# Altium schematic sheet 01_Interconnect.SchDoc(01_Interconnect)
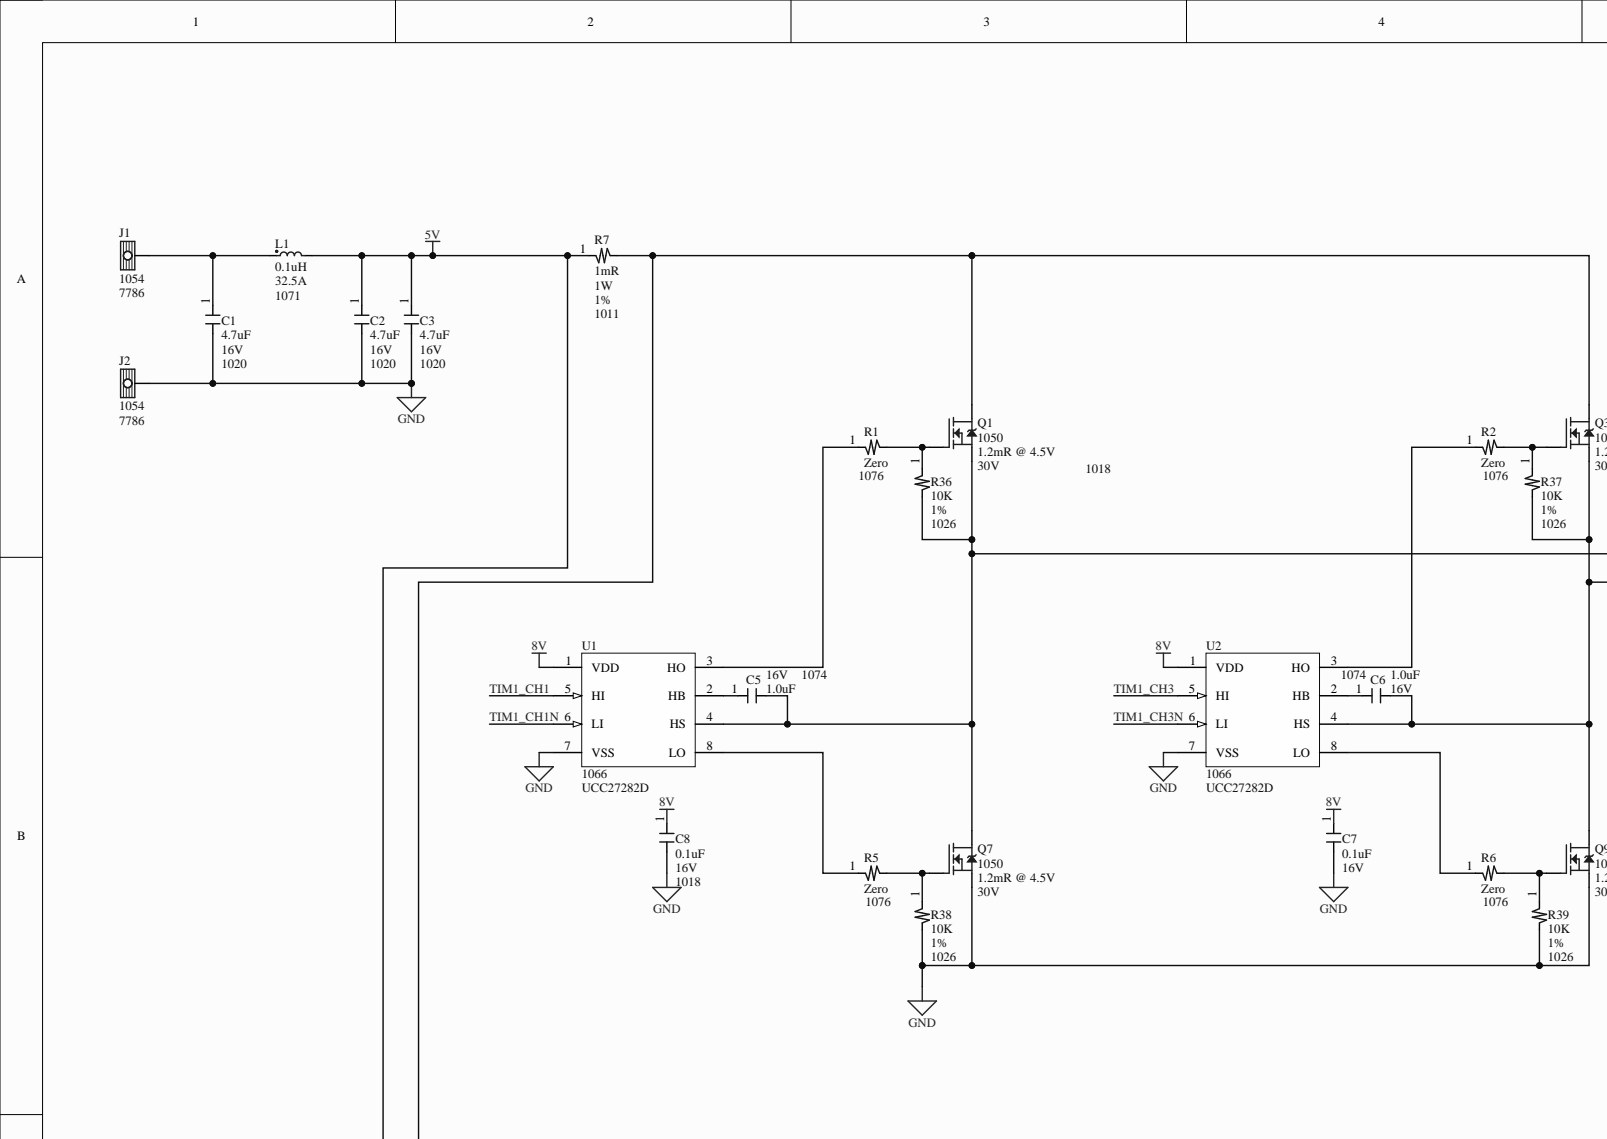
[diagram p0: 01_Interconnect.SchDoc, top left]
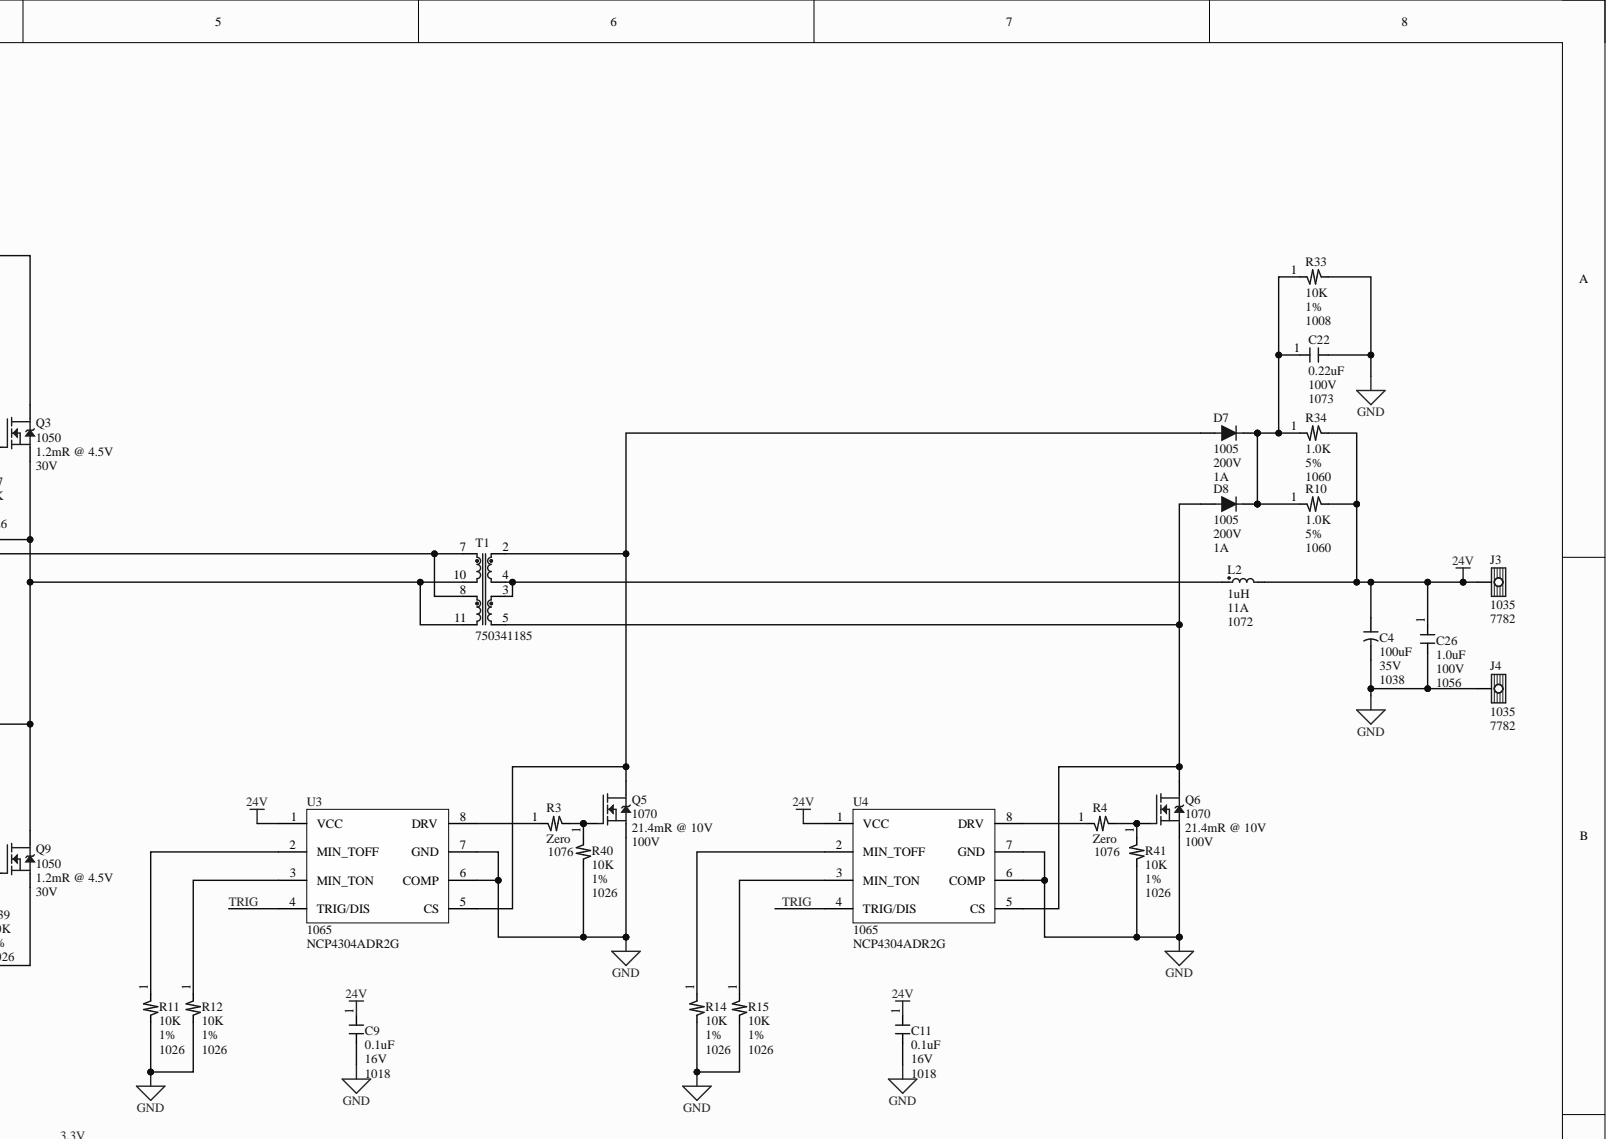
[diagram p1: 01_Interconnect.SchDoc, top right]
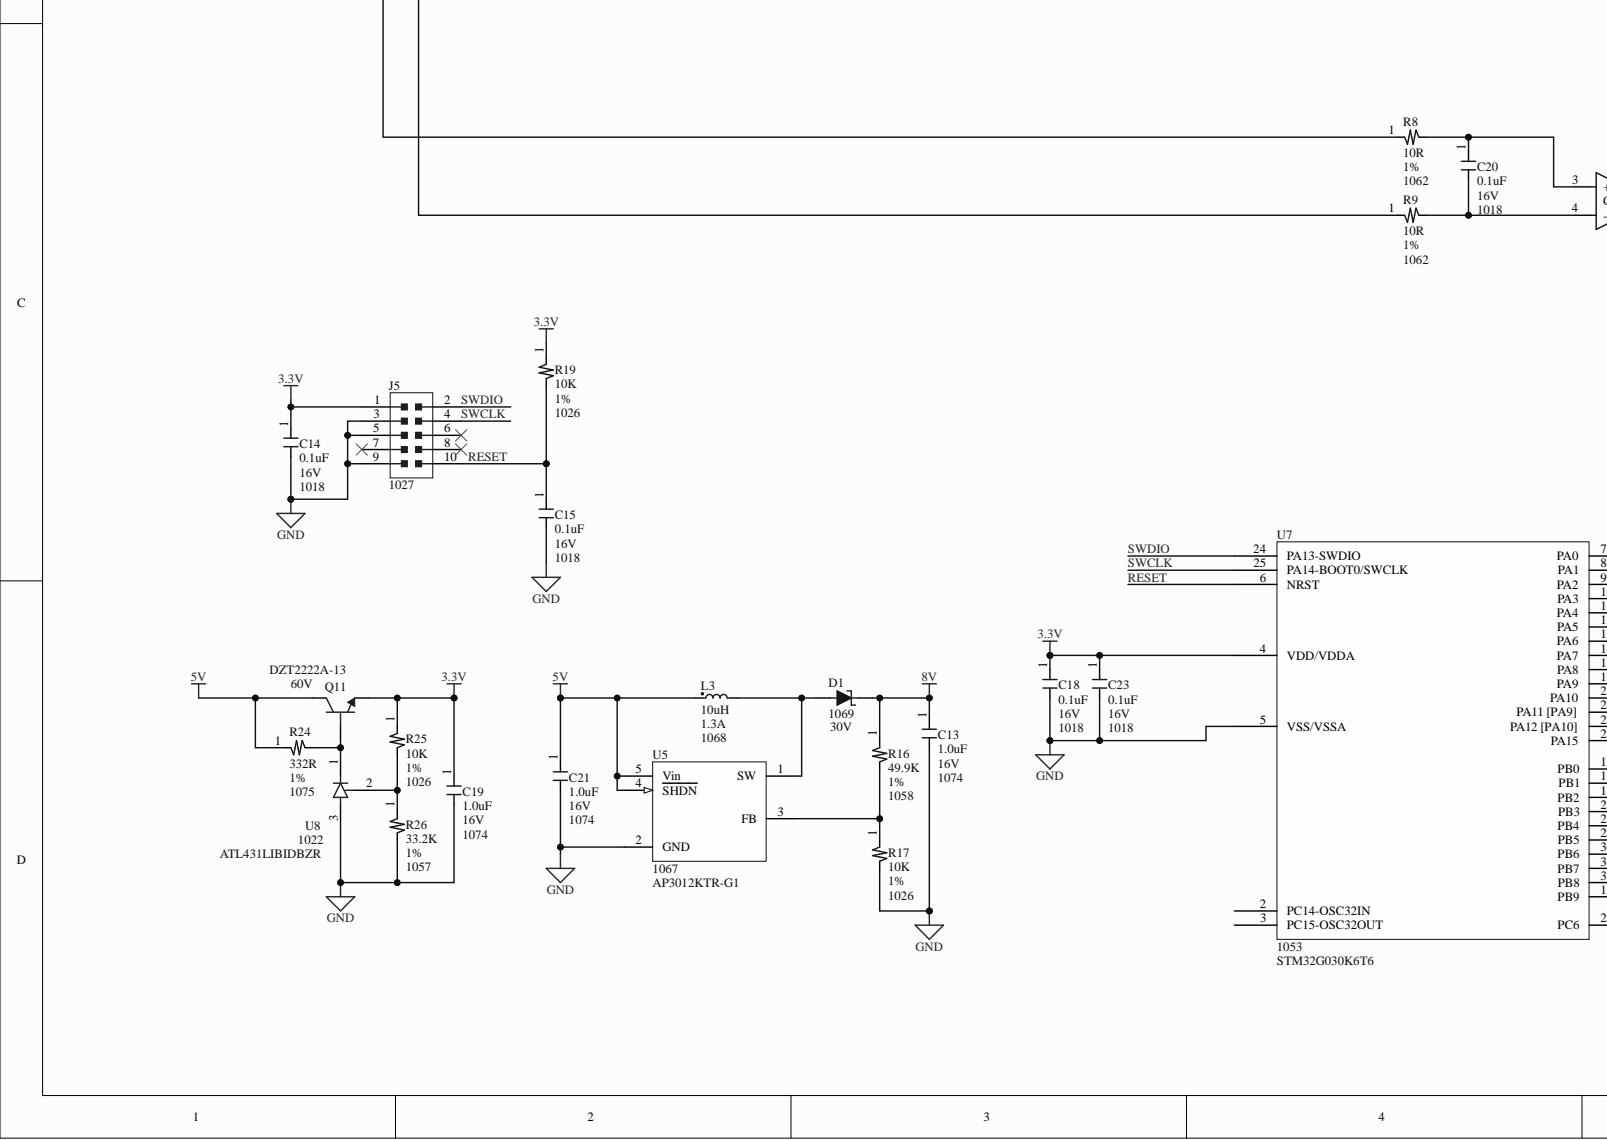
[diagram p2: 01_Interconnect.SchDoc, bottom left]
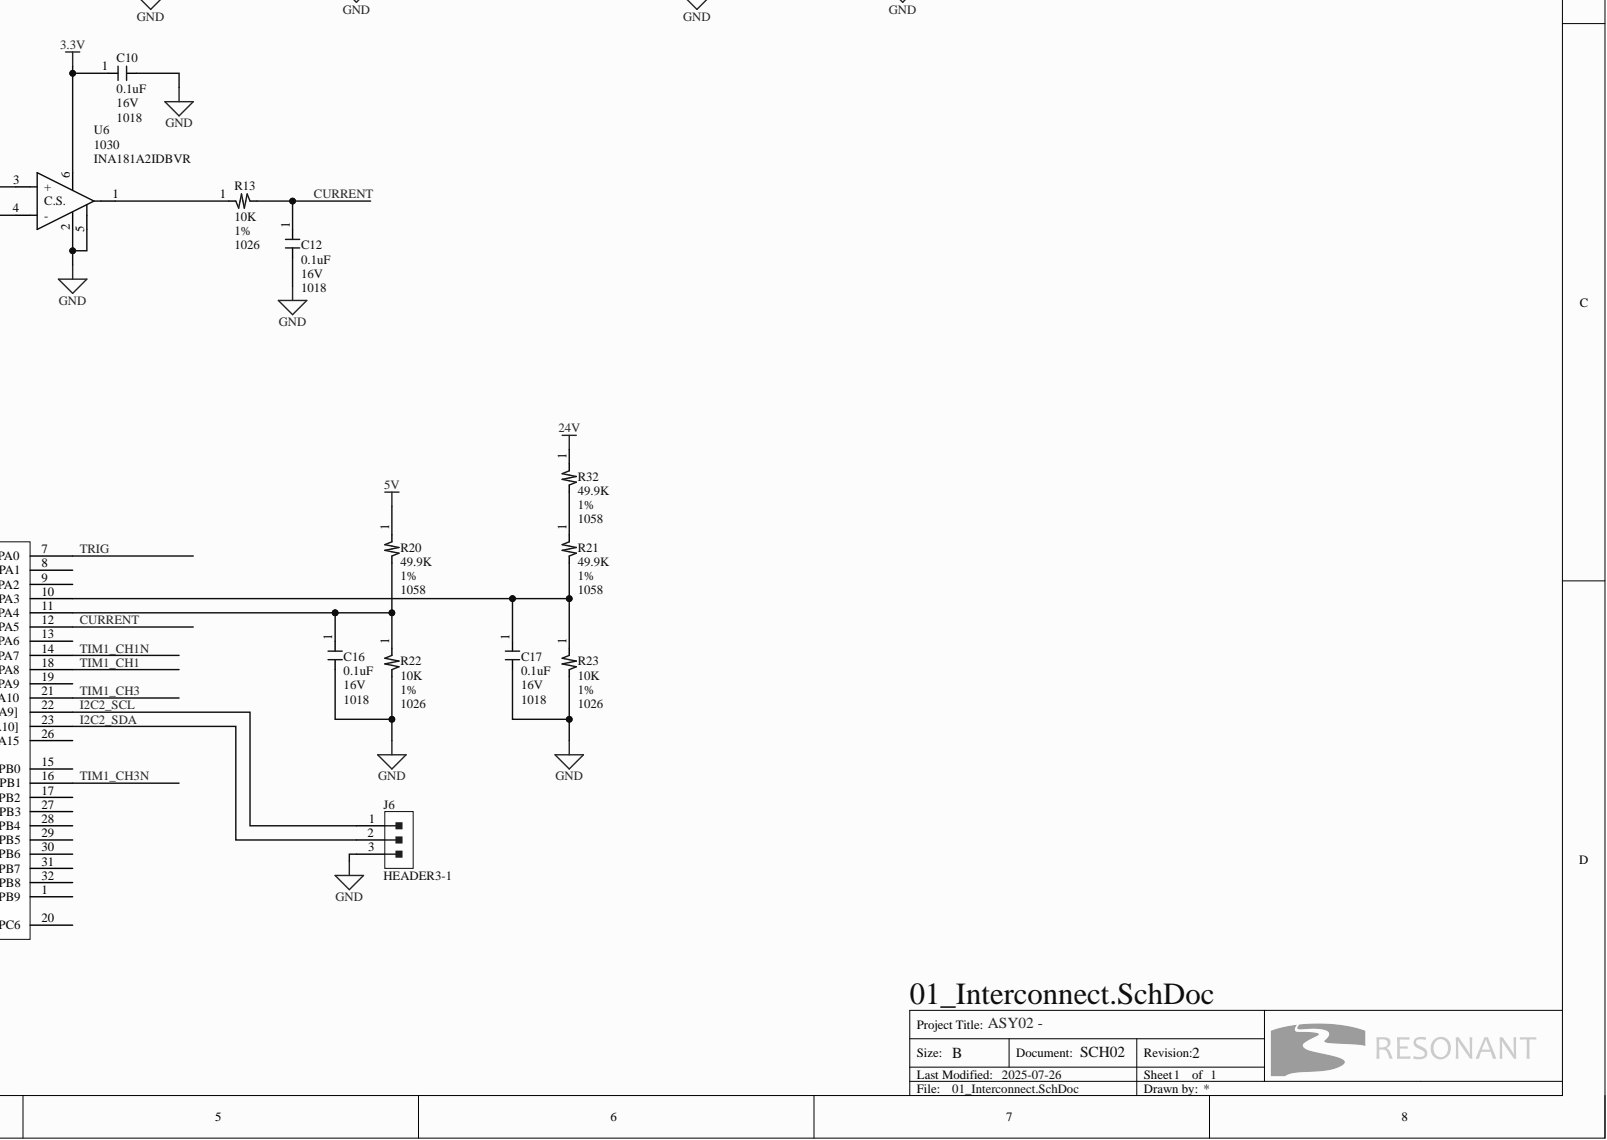
[diagram p3: 01_Interconnect.SchDoc, bottom right]

## Parts
C1  1020  value 4.7uF  Voltage: 16V  [CAPC0805]
C2  1020  value 4.7uF  Voltage: 16V  [CAPC0805]
C3  1020  value 4.7uF  Voltage: 16V  [CAPC0805]
C4  1038  value 100uF  Voltage: 35V  [PAN:D8]
C5  1074  value 1.0uF  Voltage: 16V  [CAPC0805]
C6  1074  value 1.0uF  Voltage: 16V  [CAPC0805]
C7  value 0.1uF  Voltage: 16V  [CAPC0603]  (hidden comment: 1018)
C8  1018  value 0.1uF  Voltage: 16V  [CAPC0603]
C9  1018  value 0.1uF  Voltage: 16V  [CAPC0603]
C10  1018  value 0.1uF  Voltage: 16V  [CAPC0603]
C11  1018  value 0.1uF  Voltage: 16V  [CAPC0603]
C12  1018  value 0.1uF  Voltage: 16V  [CAPC0603]
C13  1074  value 1.0uF  Voltage: 16V  [CAPC0805]
C14  1018  value 0.1uF  Voltage: 16V  [CAPC0603]
C15  1018  value 0.1uF  Voltage: 16V  [CAPC0603]
C16  1018  value 0.1uF  Voltage: 16V  [CAPC0603]
C17  1018  value 0.1uF  Voltage: 16V  [CAPC0603]
C18  1018  value 0.1uF  Voltage: 16V  [CAPC0603]
C19  1074  value 1.0uF  Voltage: 16V  [CAPC0805]
C20  1018  value 0.1uF  Voltage: 16V  [CAPC0603]
C21  1074  value 1.0uF  Voltage: 16V  [CAPC0805]
C22  1073  value 0.22uF  Voltage: 100V  [CAPC0805]
C23  1018  value 0.1uF  Voltage: 16V  [CAPC0603]
C26  1056  value 1.0uF  Voltage: 100V  [CAPC1210]
D1  1069  Voltage Rating (DC): 30V  [DO-219AB]
D7  1005  [DO-214AC]
D8  1005  Current Rating: 1A  Voltage Rating (DC): 200V  [DO-214AC]
J1  1054  Manufacturer Part No.: 7786  [KEY:7786]
J2  1054  Manufacturer Part No.: 7786  [KEY:7786]
J3  1035  Manufacturer Part No.: 7782  [KEY:7782]
J4  1035  Manufacturer Part No.: 7782  [KEY:7782]
J5  1027  [FCI:20021121-00X10XXLF]
J6  HEADER3-1  [HEADER3]
L1  1071  value 0.1uH  Max DC Current: 32.5A  [PLS:Px4341.XXXNLT]
L2  1072  value 1uH  Max DC Current: 11A  [PLS:Px4341.XXXNLT]
L3  1068  value 10uH  Max DC Current: 1.3A  [BRNS:SRN4018]
Q1  1050  Voltage: 30V  [MO-235]
Q3  1050  Voltage: 30V  [MO-235]
Q5  1070  Voltage: 100V  [MO-235]
Q6  1070  Voltage: 100V  [MO-235]
Q7  1050  Voltage: 30V  [MO-235]
Q9  1050  Voltage: 30V  [MO-235]
Q11  Manufacturing Part No.: DZT2222A-13  Voltage: 60V  [TO-261AA.BCE]  (hidden comment: 1023)
R1  1076  value Zero  [RESC0603]
R2  1076  value Zero  [RESC0603]
R3  1076  value Zero  [RESC0603]
R4  1076  value Zero  [RESC0603]
R5  1076  value Zero  [RESC0603]
R6  1076  value Zero  [RESC0603]
R7  1011  value 1mR  Power: 1W  Tolerance: 1%  [RESC2512W]
R8  1062  value 10R  Tolerance: 1%  [RESC0603]
R9  1062  value 10R  Tolerance: 1%  [RESC0603]
R10  1060  value 1.0K  Tolerance: 5%  [RESC0805]
R11  1026  value 10K  Tolerance: 1%  [RESC0603]
R12  1026  value 10K  Tolerance: 1%  [RESC0603]
R13  1026  value 10K  Tolerance: 1%  [RESC0603]
R14  1026  value 10K  Tolerance: 1%  [RESC0603]
R15  1026  value 10K  Tolerance: 1%  [RESC0603]
R16  1058  value 49.9K  Tolerance: 1%  [RESC0603]
R17  1026  value 10K  Tolerance: 1%  [RESC0603]
R19  1026  value 10K  Tolerance: 1%  [RESC0603]
R20  1058  value 49.9K  Tolerance: 1%  [RESC0603]
R21  1058  value 49.9K  Tolerance: 1%  [RESC0603]
R22  1026  value 10K  Tolerance: 1%  [RESC0603]
R23  1026  value 10K  Tolerance: 1%  [RESC0603]
R24  1075  value 332R  Tolerance: 1%  [RESC0603]
R25  1026  value 10K  Tolerance: 1%  [RESC0603]
R26  1057  value 33.2K  Tolerance: 1%  [RESC0603]
R32  1058  value 49.9K  Tolerance: 1%  [RESC0603]
R33  1008  value 10K  Tolerance: 1%  [RESC0805X25N]
R34  1060  value 1.0K  [RESC0805]
R36  1026  value 10K  Tolerance: 1%  [RESC0603]
R37  1026  value 10K  Tolerance: 1%  [RESC0603]
R38  1026  value 10K  Tolerance: 1%  [RESC0603]
R39  1026  value 10K  Tolerance: 1%  [RESC0603]
R40  1026  value 10K  Tolerance: 1%  [RESC0603]
R41  1026  value 10K  Tolerance: 1%  [RESC0603]
T1  Manufacturing Part No.: 750341185  [WUR:750341185]  (hidden comment: 1064)
U1  1066  Manufacturer Part No.: UCC27282D  [MS-012AA]
U2  1066  Manufacturer Part No.: UCC27282D  [MS-012AA]
U3  1065  Manufacturer Part No.: NCP4304ADR2G  [MS-012AA]
U4  1065  Manufacturer Part No.: NCP4304ADR2G  [MS-012AA]
U5  1067  Manufacturer Part Number: AP3012KTR-G1  [MO-178AA]
U6  1030  Manufacturer Part No.: INA181A2IDBVR  [MO-193AA]
U7  1053  Manufacturer Part No.: STM32G030K6T6  [MS-026BBA]
U8  1022  Manufacturer Part Number: ATL431LIBIDBZR  [TO-236AB.123]

## Nets
3.3V: C10.1 C14.1 C18.1 C19.1 C23.1 J5.1 Q11.E R19.1 R25.1 U6.6 U7.4(VDD/VDDA)
5V: C2.1 C3.1 C21.1 L1.2 L3.1 Q11.C R7.1 R8.1 R20.1 R24.1 U5.4(SHDN) U5.5(Vin)
8V: C7.1 C8.1 C13.1 D1.K R16.1 U1.1(VDD) U2.1(VDD)
24V: C4.P C9.1 C11.1 C26.1 J3.1 L2.2 R10.2 R32.1 R34.2 U3.1(VCC) U4.1(VCC)
GND: C1.2 C2.2 C3.2 C4.N C7.2 C8.2 C9.2 C10.2 C11.2 C12.2 C13.2 C14.2 C15.2 C16.2 C17.2 C18.2 C19.2 C21.2 C22.2 C23.2 C26.2 J2.1 J4.1 J5.3 J5.5 J5.9 J6.3 Q5.S Q6.S Q7.S Q9.S R11.2 R12.2 R14.2 R15.2 R17.2 R22.2 R23.2 R26.2 R33.2 R38.2 R39.2 R40.2 R41.2 U1.7(VSS) U2.7(VSS) U3.6(COMP) U3.7(GND) U4.6(COMP) U4.7(GND) U5.2(GND) U6.2 U6.5 U7.5(VSS/VSSA) U8.3
CURRENT: C12.1 R13.2 U7.12(PA5)
I2C2_SCL: J6.1 U7.22(PA11_[PA9])
I2C2_SDA: J6.2 U7.23(PA12_[PA10])
RESET: C15.1 J5.10 R19.2 U7.6(NRST)
SWCLK: J5.4 U7.25(PA14-BOOT0/SWCLK)
SWDIO: J5.2 U7.24(PA13-SWDIO)
TIM1_CH1: U1.5(HI) U7.18(PA8)
TIM1_CH1N: U1.6(LI) U7.14(PA7)
TIM1_CH3: U2.5(HI) U7.21(PA10)
TIM1_CH3N: U2.6(LI) U7.16(PB1)
TRIG: U3.4(TRIG/DIS) U4.4(TRIG/DIS) U7.7(PA0)
NetC1_1: C1.1 J1.1 L1.1
NetC5_1: C5.1 U1.2(HB)
NetC5_2: C5.2 Q1.S Q7.D R36.2 T1.7 T1.8 U1.4(HS)
NetC6_1: C6.1 U2.2(HB)
NetC6_2: C6.2 Q3.S Q9.D R37.2 T1.10 T1.11 U2.4(HS)
NetC16_1: C16.1 R20.2 R22.1 U7.11(PA4)
NetC17_1: C17.1 R21.2 R23.1 U7.10(PA3)
NetC20_1: C20.1 R8.2 U6.3(+)
NetC20_2: C20.2 R9.2 U6.4(-)
NetC22_1: C22.1 D7.K D8.K R10.1 R33.1 R34.1
NetD1_A: D1.A L3.2 U5.1(SW)
NetD7_A: D7.A Q5.D T1.2 U3.5(CS)
NetD8_A: D8.A Q6.D T1.5 U4.5(CS)
NetL2_1: L2.1 T1.3 T1.4
NetQ1_D: Q1.D Q3.D R7.2 R9.1
NetQ1_G: Q1.G R1.2 R36.1
NetQ3_G: Q3.G R2.2 R37.1
NetQ5_G: Q5.G R3.2 R40.1
NetQ6_G: Q6.G R4.2 R41.1
NetQ7_G: Q7.G R5.2 R38.1
NetQ9_G: Q9.G R6.2 R39.1
NetQ11_B: Q11.B R24.2 U8.1
NetR1_1: R1.1 U1.3(HO)
NetR2_1: R2.1 U2.3(HO)
NetR3_1: R3.1 U3.8(DRV)
NetR4_1: R4.1 U4.8(DRV)
NetR5_1: R5.1 U1.8(LO)
NetR6_1: R6.1 U2.8(LO)
NetR11_1: R11.1 U3.2(MIN_TOFF)
NetR12_1: R12.1 U3.3(MIN_TON)
NetR13_1: R13.1 U6.1
NetR14_1: R14.1 U4.2(MIN_TOFF)
NetR15_1: R15.1 U4.3(MIN_TON)
NetR16_2: R16.2 R17.1 U5.3(FB)
NetR21_1: R21.1 R32.2
NetR25_2: R25.2 R26.1 U8.2

Not connected: J5 6 7 8; U7 1(PB9) 2(PC14-OSC32IN) 3(PC15-OSC32OUT) 8(PA1) 9(PA2) 13(PA6) 15(PB0) 17(PB2) 19(PA9) 20(PC6) 26(PA15) 27(PB3) 28(PB4) 29(PB5) 30(PB6) 31(PB7) 32(PB8)

## Library data of the parts
C1-C3 = CMP-001-00006-2: Capacitor, Ceramic, 4.7uF, 16V, 0805; Component Kind: Standard; Library Name: Vecima Networks, Inc. - Power Supply Division
C4 = CMP-001-00005-1: Capacitor, ALEL, 100uF,35V, SMD; ESR (Equivalent Series Resistance): 160mR; Ripple Current: 600mA; Component Kind: Standard; Library Name: Vecima Networks, Inc. - Power Supply Division
C5 C6 C13 C19 C21 = CMP-001-00009-1: Capacitor, Ceramic, 1.0uF, 16V, 0805; Component Kind: Standard; Library Name: Vecima Networks, Inc. - Power Supply Division
C7-C12 C14-C18 C20 C23 = CMP-001-00003-1: Capacitor, Ceramic, 0.1uF, 16V, 0603; Component Kind: Standard; Library Name: Vecima Networks, Inc. - Power Supply Division
C22 = CMP-001-00008-1: Capacitor, Ceramic, 0.22uF, 100V, 0805; Component Kind: Standard; Library Name: Vecima Networks, Inc. - Power Supply Division
C26 = CMP-001-00007-1: Capacitor, Ceramic, 1.0uF, 100V, 1210; Component Kind: Standard; Library Name: Vecima Networks, Inc. - Power Supply Division
D1 = CMP-004-00003-1: Diode, Schottky, 30V, 1A, DO-219AB, SL03-GS18; Current Rating: 1.1A; Component Kind: Standard; Library Name: Vecima Networks, Inc. - Power Supply Division
D7 D8 = CMP-004-00001-2: Diode, PN, 200V, 1A; Component Kind: Standard; Library Name: Vecima Networks, Inc. - Power Supply Division
  D7: Current Rating: 1A; Voltage Rating (DC): 200V
J1 J2 = CMP-002-00002-1: Terminal, Bug, 6-32, 30A, PTH; Manufactuter: Keystone; ClassName: BUG; Component Kind: Standard; Library Name: Vecima Networks, Inc. - Power Supply Division
J3 J4 = CMP-002-00001-1: Terminal, Bug, 6-32, PTH; Manufactuter: Keystone; ClassName: BUG; Component Kind: Standard; Library Name: Vecima Networks, Inc. - Power Supply Division
J5 = CMP-002-00000-1: Connector, Square, 2x5, 0.05", SMT, Debugger; Manufacturer: FCI; Manufacturer Part No.: 20021121-00010T1LF; Component Kind: Standard; Library Name: Vecima Networks, Inc. - Power Supply Division
J6 = CMP-002-00003-2: Mechanical, Header 3, 0.05" Pitch; ClassName: CONN; Component Kind: Standard; Library Name: Vecima Networks, Inc. - Power Supply Division
L1 = CMP-006-00007-1: Inductor, 0.1uH, 32.5A, SMD; Component Kind: Standard; Library Name: Vecima Networks, Inc. - Power Supply Division
L2 = CMP-006-00008-1: Inductor, 1uH, 11A, SMD; Component Kind: Standard; Library Name: Vecima Networks, Inc. - Power Supply Division
L3 = CMP-006-00006-1: Inductor, 10uH, 1.3A, SMD; Component Kind: Standard; Library Name: Vecima Networks, Inc. - Power Supply Division
Q1 Q3 Q7 Q9 = CMP-013-00006-2: MOSFET, 30V, 1.2mR, POWER-S8; Current: 100A; Rds: 1.2mR @ 4.5V; Component Kind: Standard; Library Name: Vecima Networks, Inc. - Power Supply Division
Q5 Q6 = CMP-013-00008-1: MOSFET, 100V, 27.5mR, POWER-S8; Current: 42A; Rds: 21.4mR @ 10V; Component Kind: Standard; Library Name: Vecima Networks, Inc. - Power Supply Division
Q11 = CMP-013-00001-1: BJT, NPN, 60V, 1W, SOT223-4; Manufacturer: Diodes Inc.; Current: 600mA; Component Kind: Standard; Library Name: Vecima Networks, Inc. - Power Supply Division
R1-R6 = CMP-009-00010-1: Resistor, Signal, Zero, 1/8W, 0603; Component Kind: Standard; Power: 1/8W; Library Name: Vecima Networks, Inc. - Power Supply Division
R7 = CMP-009-00001-2: Resistor, Current Sense, 1mR, 2512W; Component Kind: Standard; Library Name: Vecima Networks, Inc. - Power Supply Division
R8 R9 = CMP-009-00008-1: Resistor, Signal, 10R, 1%, 1/8W, 0603; Component Kind: Standard; Power: 1/8W; Library Name: Vecima Networks, Inc. - Power Supply Division
R10 R34 = CMP-009-00006-1: Resistor, Signal, 1.0K, 5%, 1/2W, 0805; Component Kind: Standard; Power: 1/2W; Library Name: Vecima Networks, Inc. - Power Supply Division
  R34: Tolerance: 5%
R11-R15 R17 R19 R22 R23 R25 R36-R41 = CMP-009-00002-2: Resistor, Signal, 10K, 1%, 1/10W, 0603; Component Kind: Standard; Power: 1/10W; Library Name: Vecima Networks, Inc. - Power Supply Division
R16 R20 R21 R32 = CMP-009-00004-1: Resistor, Signal, 49.9K, 1%, 1/8W, 0603; Component Kind: Standard; Power: 1/8W; Library Name: Vecima Networks, Inc. - Power Supply Division
R24 = CMP-009-00009-1: Resistor, Signal, 332R, 1%, 1/8W, 0603; Component Kind: Standard; Power: 1/8W; Library Name: Vecima Networks, Inc. - Power Supply Division
R26 = CMP-009-00003-1: Resistor, Signal, 33.2K, 1%, 1/8W, 0603; Component Kind: Standard; Power: 1/8W; Library Name: Vecima Networks, Inc. - Power Supply Division
R33 = CMP-009-00000-2: Resistor, Signal, 10K, 1%, 1/8W, 0805; Component Kind: Standard; Power: 1/8W; Library Name: Vecima Networks, Inc. - Power Supply Division
T1 = CMP-012-00005-1: Transformers, Planar, 48V, 250W; Manufacturer: Wurth; Component Kind: Standard; Library Name: Vecima Networks, Inc. - Power Supply Division
U1 U2 = CMP-001-0018-2: Gate Drivers, Half Bridge, 3A, SOIC8; Manufacturer: TI; Component Kind: Standard; Current: 3A; Library Name: Vecima Networks, Inc. - Power Supply Division
U3 U4 = CMP-001-0019-1: SSSR Driver, NCP4304; Manufacturer: ON Semi; Current: 5A; Component Kind: Standard; Library Name: Vecima Networks, Inc. - Power Supply Division
U5 = CMP-001-0020-1: IC, PMIC, Boost Converter, 500mA, AP3012; Manufacturer: Diodes; Component Kind: Standard; Library Name: Vecima Networks, Inc. - Power Supply Division
U6 = CMP-001-0008-2: IC, Current Sense, 50x, 210 kHZ, SOT23; Manufacturer: TI; Component Kind: Standard; Library Name: Vecima Networks, Inc. - Power Supply Division
U7 = CMP-001-0017-1: IC, Microcontroller, STM32G030K6T6; Manufacturer: ST; Component Kind: Standard; Library Name: Vecima Networks, Inc. - Power Supply Division
U8 = CMP-001-0007-1: IC, References, ATL431, SOT-23; Manufacturer: Texas Instruments; Tolerance: 0.5%; Component Kind: Standard; Library Name: Vecima Networks, Inc. - Power Supply Division
